# Altium schematic sheet Connectors & Regulators.SchDoc
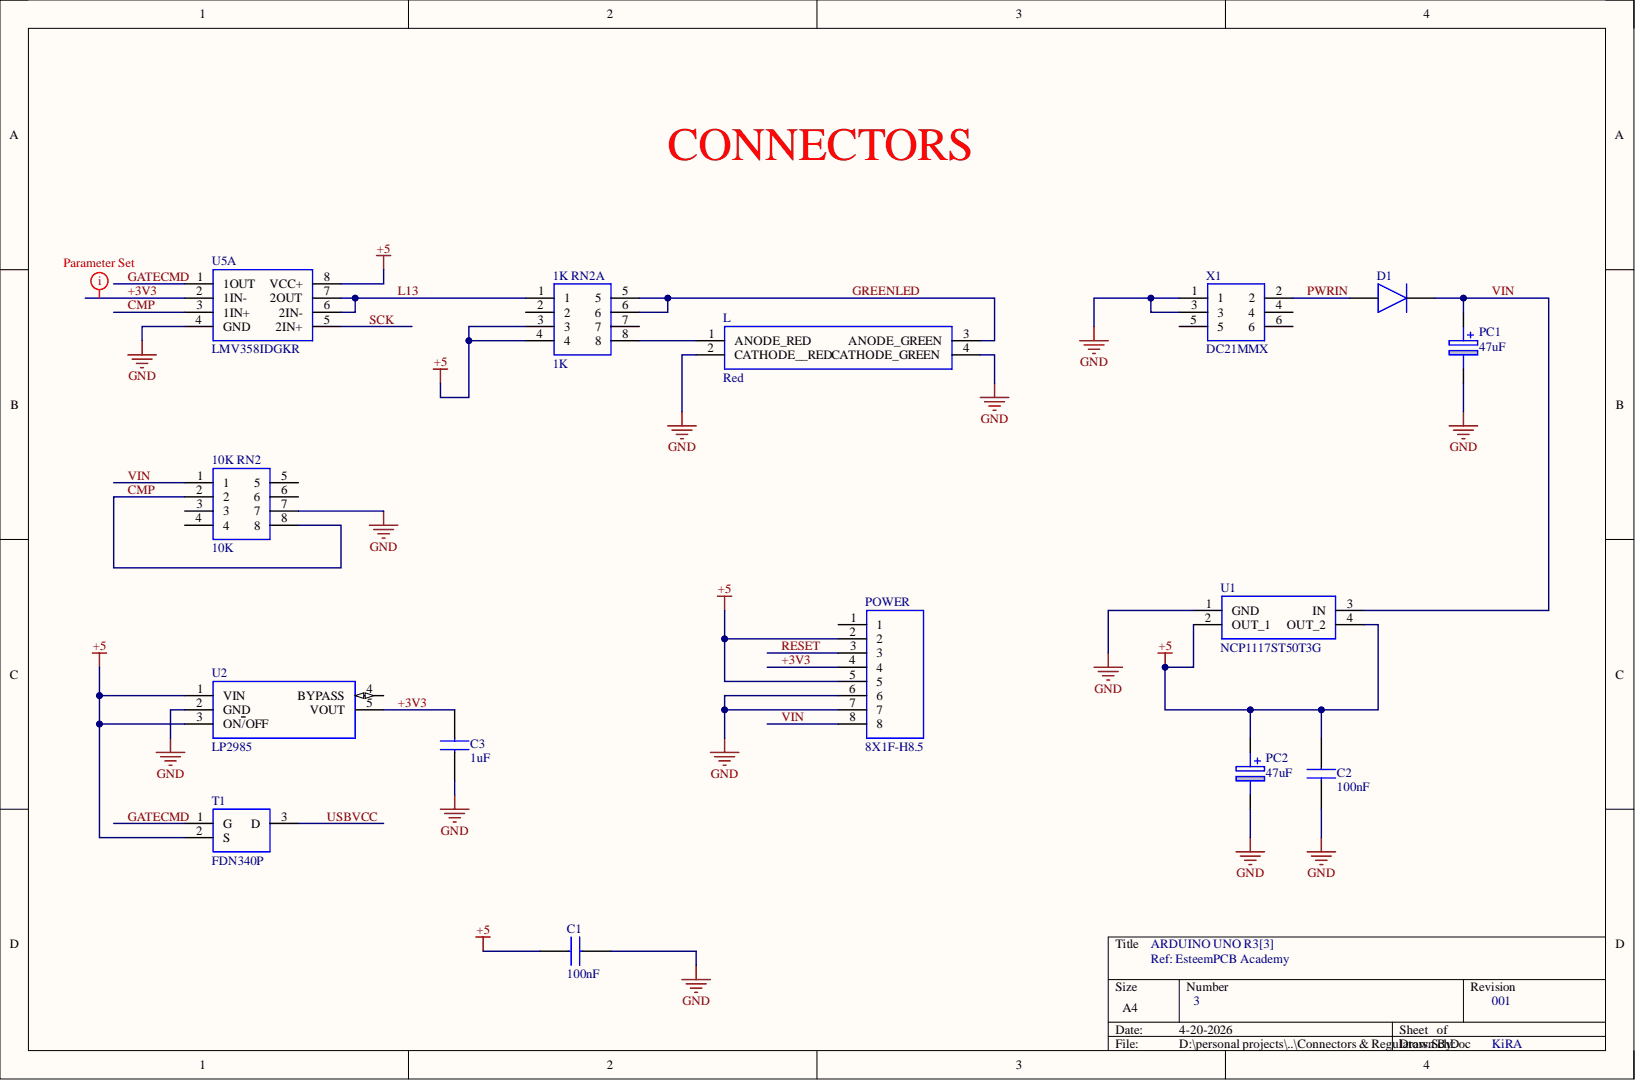
[diagram p0: Connectors & Regulators.SchDoc]

## Parts
1K_RN2A  1K  [CAY10-XXXJ4]
10K_RN2  10K  [CAY10-XXXJ4]
C1  100nF  [CAPC2012X130N]
C2  100nF  [CAPC2012X130N]
C3  1uF  [CAPC2012X145N]
D1  CD1206-S01575  [DIOC3016X65N]  (value not drawn)
L  Red  [SML522MD8WT86]
PC1  47uF  [CAPAE530X610N]
PC2  47uF  [CAPAE530X610N]
POWER  8X1F-H8.5  [HDRV8W90P0X254_1X8_2032X249X560P]
T1  FDN340P  [SOT95P251X112-3N]
U1  NCP1117ST50T3G  [SOT230P700X180-4N]
U2  LP2985  [SOT95P280X145-5N]
U5A  LMV358IDGKR  [SOP65P490X110-8N]
X1  DC21MMX  [USBBSRA]

## Nets
+5: 1K_RN2A.3 1K_RN2A.4 C1.1 C2.1 PC2.1 POWER.2 POWER.5 T1.2 U1.2(OUT_1) U1.4(OUT_2) U2.1(VIN) U2.3(ON/OFF) U5A.8(VCC+)
GND: 10K_RN2.7 C1.2 C2.2 C3.2 L.2(CATHODE__RED) L.4(CATHODE_GREEN) PC1.2 PC2.2 POWER.6 POWER.7 U1.1(GND) U2.2(GND) U5A.4(GND) X1.1 X1.3
+3V3: C3.1 POWER.4 U2.5(VOUT) U5A.2(1IN-)
CMP: 10K_RN2.2 10K_RN2.8 U5A.3(1IN+)
GATECMD: T1.1 U5A.1(1OUT)
GREENLED: 1K_RN2A.5 1K_RN2A.6 L.3(ANODE_GREEN)
L13: 1K_RN2A.1 U5A.6(2IN-) U5A.7(2OUT)
PWRIN: D1.2 X1.2
RESET: POWER.3
SCK: U5A.5(2IN+)
USBVCC: T1.3
VIN: 10K_RN2.1 D1.1 PC1.1 POWER.8 U1.3(IN)
Net1K RN2A_8: 1K_RN2A.8 L.1(ANODE_RED)

Not connected: 1K_RN2A 2 7; 10K_RN2 3 4 5 6; POWER 1; U2 4(BYPASS); X1 4 5 6

## Library data of the parts
1K_RN2A 10K_RN2 = CAY10-220J4LF: CAY10 convex chip resistor array,22R Bourns CAY10 Series 22 5% Isolated SMT Resistor Array, 4 Resistors, 0.125W total 0804 package Convex; Allied_Number: 70276318; Allied Price/Stock: https://www.alliedelec.com/bourns-cay10-220j4lf/70276318/; Component Kind: Standard; Datasheet Link: http://docs-emea.rs-online.com/webdocs/064e/0900766b8064e463.pdf; Manufacturer_Name: Bourns; Manufacturer_Part_Number: CAY10-220J4LF; Mouser Part Number: 652-CAY10-220J4LF; Mouser Price/Stock: https://www.mouser.com/Search/Refine.aspx?Keyword=652-CAY10-220J4LF; RS Part Number: 1674746; RS Price/Stock: http://uk.rs-online.com/web/p/products/1674746
  1K_RN2A: Library Name: 1K RN2C.SchLib
  10K_RN2: Library Name: 10K RN1C.SchLib
C1 C2 = 0805Y0500104KXT: Syfer Technology 0805 Flexicap 100nF Ceramic Multilayer Capacitor, 50 V, +125C, X7R Dielectric, 10% SMD; Geometry.Height: 1.3mm; Component Kind: Standard; Datasheet Link: http://docs-emea.rs-online.com/webdocs/119d/0900766b8119d7bc.pdf; Library Name: 100nF.SchLib; Manufacturer_Name: Syfer; Manufacturer_Part_Number: 0805Y0500104KXT; Mouser Part Number: 7738389P; Mouser Price/Stock: http://uk.rs-online.com/web/p/products/7738389P; RS Part Number: 7738389P; RS Price/Stock: http://uk.rs-online.com/web/p/products/7738389P
C3 = C2012C0G2A103J125AA: Multilayer Ceramic Capacitors MLCC - SMD/SMT 0805 C0G 100volts 0.01uF 5% 1.25mm; Manufacturer_Name: TDK; Geometry.Height: 1.45mm; Component Kind: Standard; Datasheet Link: https://product.tdk.com/info/en/catalog/datasheets/mlcc_commercial_midvoltage_en.pdf; Library Name: 1uF.SchLib; Manufacturer_Part_Number: C2012C0G2A103J125AA; Mouser Part Number: 810-C2012C0G2A103JAA; Mouser Price/Stock: https://www.mouser.com/Search/Refine.aspx?Keyword=810-C2012C0G2A103JAA
D1 = CD1206-S01575: Diode Switching 100V 0.15A 4ns SMD1206 Bourns CD1206-S01575 Switching Diode, 150mA, 100V, 4ns, 2-Pin 1206; Allied_Number: 70154675; Geometry.Height: 0.65mm; Allied Price/Stock: http://www.alliedelec.com/bourns-cd1206-s01575/70154675/; Component Kind: Standard; Datasheet Link: http://docs-emea.rs-online.com/webdocs/1189/0900766b811897a9.pdf; Library Name: CD1206-S01575.SchLib; Manufacturer_Name: Bourns; Manufacturer_Part_Number: CD1206-S01575; Mouser Part Number: 652-CD1206-S01575; Mouser Price/Stock: https://www.mouser.com/Search/Refine.aspx?Keyword=652-CD1206-S01575; RS Part Number: 1775431; RS Price/Stock: http://uk.rs-online.com/web/p/products/1775431
L = SML-522MU8WT86: Dual color mini-mold Chip LED: ROHM's chip LED lineup consists of standard type,top-view type, side-view type and reverse-mount type.; Manufacturer_Name: ROHM Semiconductor; Geometry.Height: 0mm; Component Kind: Standard; Datasheet Link: https://www.rohm.com/datasheet/SML-522MU8W/sml-52-e; Library Name: Yellow LED.SchLib; Manufacturer_Part_Number: SML-522MU8WT86; Mouser Part Number: 755-SML-522MU8WT86; Mouser Price/Stock: https://www.mouser.com/Search/Refine.aspx?Keyword=755-SML-522MU8WT86; RS Part Number: 1682468; RS Price/Stock: http://uk.rs-online.com/web/p/products/1682468
PC1 PC2 = EEE-FT1V470AR: Aluminum Electrolytic Capacitors - SMD 47uF 35volts 5x5.8mm SMD; Manufacturer_Name: Panasonic; Allied_Number: 70258388; Geometry.Height: 6.1mm; Allied Price/Stock: https://www.alliedelec.com/panasonic-eee-ft1v470ar/70258388/; Component Kind: Standard; Datasheet Link: https://industrial.panasonic.com/cdbs/www-data/pdf/RDE0000/ABA0000C1240.pdf; Manufacturer_Part_Number: EEE-FT1V470AR; Mouser Part Number: 667-EEE-FT1V470AR; Mouser Price/Stock: https://www.mouser.com/Search/Refine.aspx?Keyword=667-EEE-FT1V470AR; Library Name: 47uF Polar.SchLib
POWER = 90120-0768: 8 way single row straight header Molex C-GRID III Series, Series Number 90120, 2.54mm Pitch 8 Way 1 Row Straight Pin Header, Through Hole; Allied_Number: 70372836; Geometry.Height: 5.6mm; Allied Price/Stock: https://www.alliedelec.com/molex-incorporated-90120-0768/70372836/; Component Kind: Standard; Datasheet Link: http://www.molex.com/molex/products/datasheet.jsp?part=active/0901200768_PCB_HEADERS.xml; Library Name: 8X1F-H8.5.SchLib; Manufacturer_Name: Molex; Manufacturer_Part_Number: 90120-0768; Mouser Part Number: 538-90120-0768; Mouser Price/Stock: https://www.mouser.com/Search/Refine.aspx?Keyword=538-90120-0768; RS Part Number: 1671900; RS Price/Stock: http://uk.rs-online.com/web/p/products/1671900
T1 = FDN340P: FDN340P, P-channel MOSFET Transistor 2 A 20 V, 3-Pin SuperSOT; Manufacturer_Name: Fairchild Semiconductor; Geometry.Height: 1.12mm; Component Kind: Standard; Datasheet Link: http://docs-emea.rs-online.com/webdocs/0d23/0900766b80d23111.pdf; Library Name: FDN340P.SchLib; Manufacturer_Part_Number: FDN340P; Mouser Part Number: 512-FDN340P; Mouser Price/Stock: https://www.mouser.com/Search/Refine.aspx?Keyword=512-FDN340P; RS Part Number: 6710435P; RS Price/Stock: http://uk.rs-online.com/web/p/products/6710435P
U1 = NCP1117ST50T3G: ON SEMICONDUCTOR - NCP1117ST50T3G - LDO, REG, 20VIN, 1A, 5V, 1%, SOT223-3; Allied_Number: 70466898; Geometry.Height: 1.8mm; Allied Price/Stock: http://www.alliedelec.com/on-semiconductor-ncp1117st50t3g/70466898/; Component Kind: Standard; Datasheet Link: http://www.onsemi.com/pub/Collateral/NCP1117-D.PDF; Library Name: NCP1117ST50T3G.SchLib; Manufacturer_Name: ON Semiconductor; Manufacturer_Part_Number: NCP1117ST50T3G; Mouser Part Number: 863-NCP1117ST50T3G; Mouser Price/Stock: https://www.mouser.com/Search/Refine.aspx?Keyword=863-NCP1117ST50T3G; RS Part Number: 8022130P; RS Price/Stock: http://uk.rs-online.com/web/p/products/8022130P
U2 = LP2985-33DBVR: 150-mA Low-noise Low-dropout Regulator With Shutdown; Manufacturer_Name: Texas Instruments; Geometry.Height: 1.45mm; Component Kind: Standard; Datasheet Link: http://www.ti.com/lit/gpn/lp2985; Library Name: LP2985-33DBVR.SchLib; Manufacturer_Part_Number: LP2985-33DBVR; Mouser Part Number: 595-LP2985-33DBVR; Mouser Price/Stock: https://www.mouser.com/Search/Refine.aspx?Keyword=595-LP2985-33DBVR
U5A = LMV358IDGKR: OP Amp Dual GP R-R O/P 5.5V 8-Pin MSOP Texas Instruments LMV358IDGKR, Dual Op Amp, 1MHz CMOS, Rail to Rail, 3 V, 5 V, 8-Pin MSOP; Geometry.Height: 1.1mm; Component Kind: Standard; Datasheet Link: http://www.ti.com/lit/ds/symlink/lmv358.pdf; Library Name: LMV358IDGKR.SchLib; Manufacturer_Name: Texas Instruments; Manufacturer_Part_Number: LMV358IDGKR; Mouser Part Number: 595-LMV358IDGKR; Mouser Price/Stock: https://www.mouser.com/Search/Refine.aspx?Keyword=595-LMV358IDGKR; RS Part Number: 1625114; RS Price/Stock: http://uk.rs-online.com/web/p/products/1625114
X1 = USB-B-S-RA: MULTICOMP - USB-B-S-RA - USB, 2.0 TYPE B, RECEPTACLE, TH; Geometry.Height: 10mm; Component Kind: Standard; Datasheet Link: http://www.farnell.com/datasheets/1788391.pdf; Library Name: DC21MMX.SchLib; Manufacturer_Name: MULTICOMP; Manufacturer_Part_Number: USB-B-S-RA
